# Altium schematic sheet Sheet_MCU_IO.SchDoc
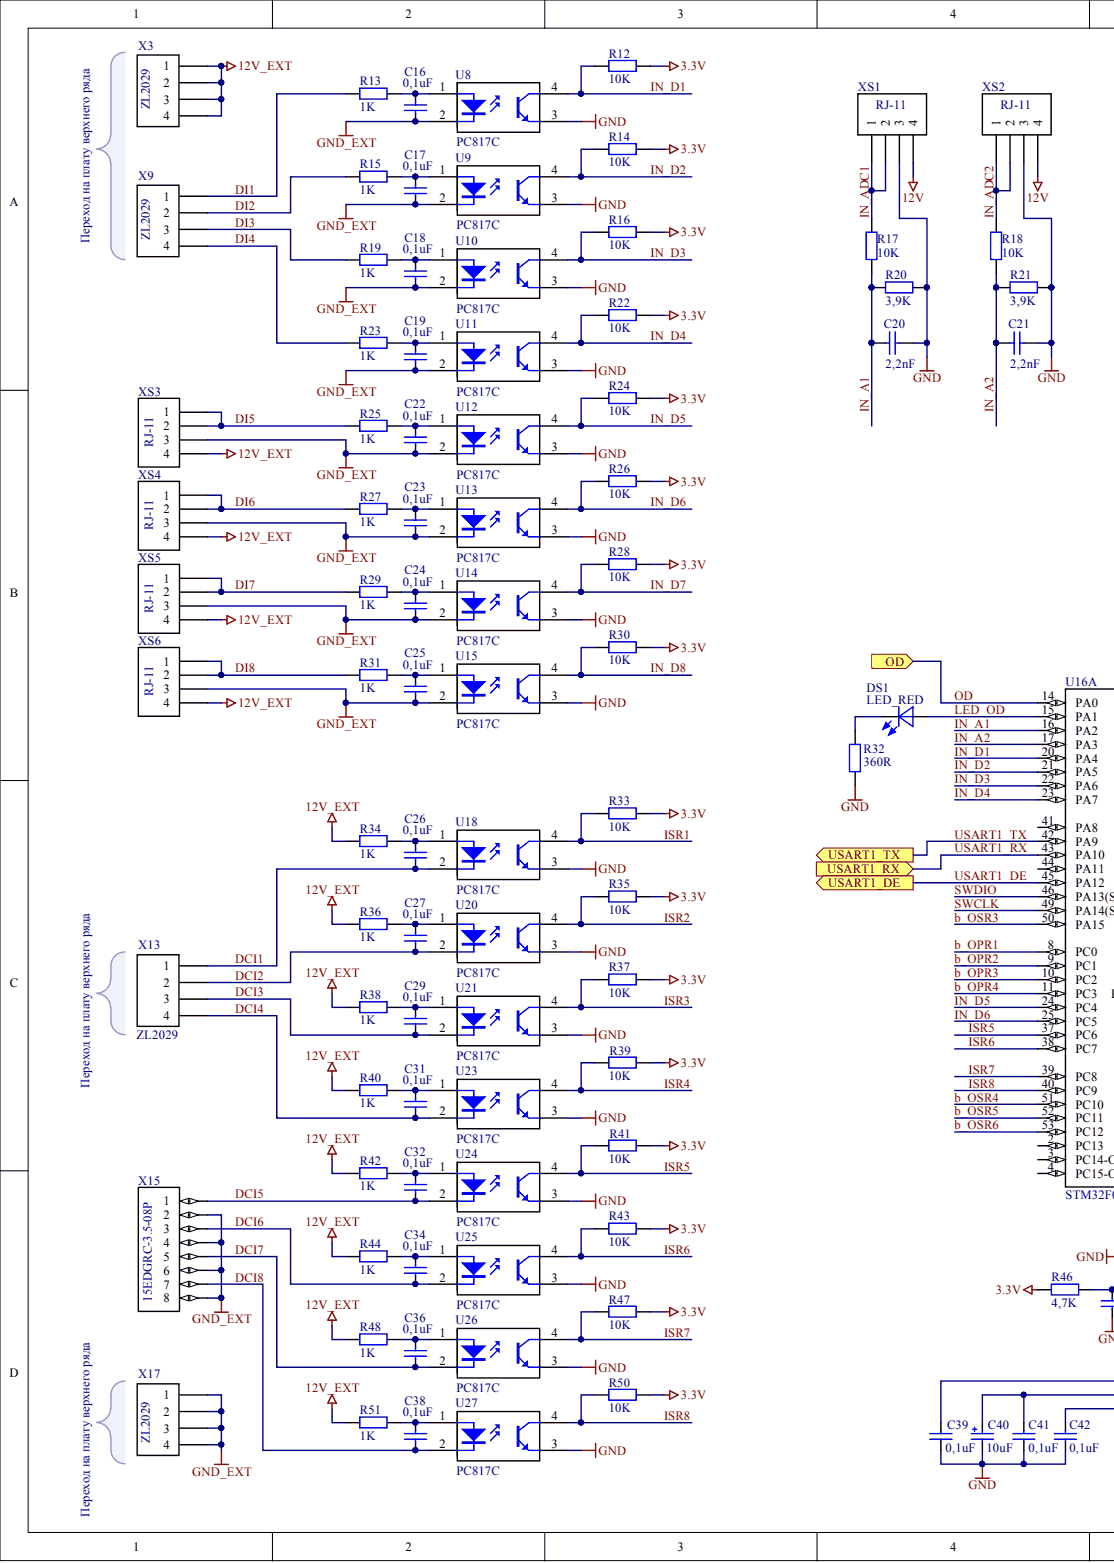
[diagram p0: Sheet_MCU_IO.SchDoc, left]
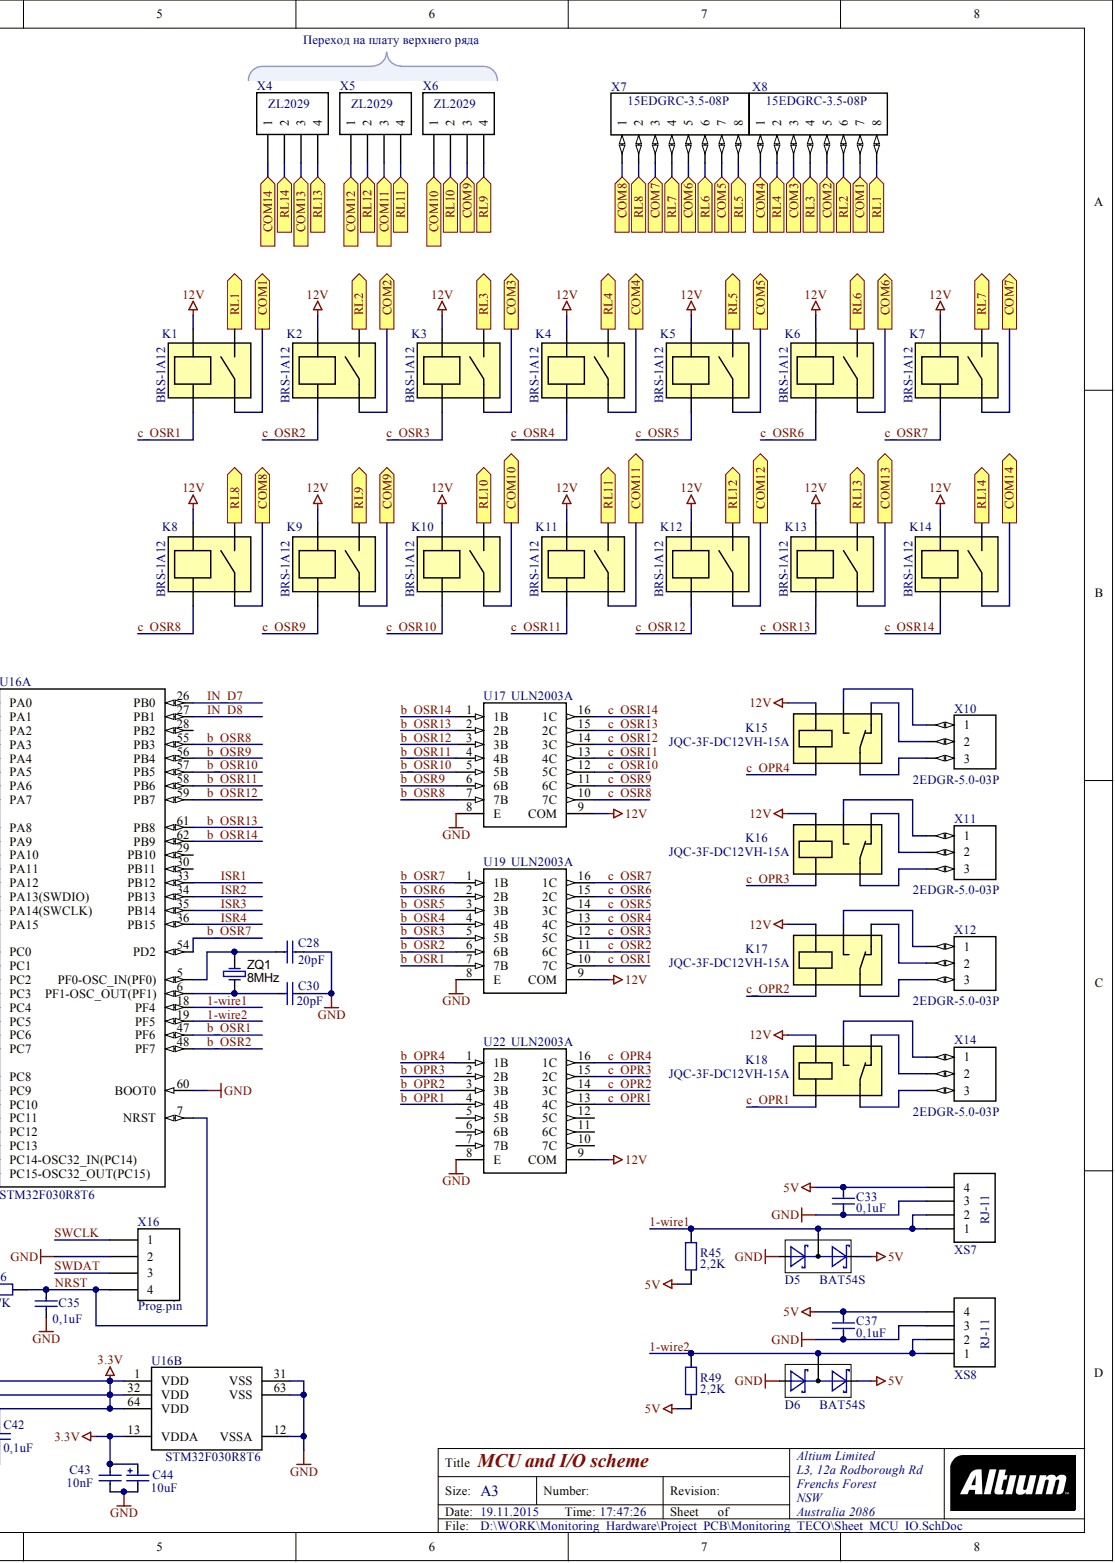
[diagram p1: Sheet_MCU_IO.SchDoc, right]

## Parts
C16  0,1uF  [C_0805]
C17  0,1uF  [C_0805]
C18  0,1uF  [C_0805]
C19  0,1uF  [C_0805]
C20  value 2,2nF  [C_0805]  (hidden comment: Cap_Semi)
C21  value 2,2nF  [C_0805]  (hidden comment: Cap_Semi)
C22  0,1uF  [C_0805]
C23  0,1uF  [C_0805]
C24  0,1uF  [C_0805]
C25  0,1uF  [C_0805]
C26  0,1uF  [C_0805]
C27  0,1uF  [C_0805]
C28  20pF  [C_0805]
C29  0,1uF  [C_0805]
C30  20pF  [C_0805]
C31  0,1uF  [C_0805]
C32  0,1uF  [C_0805]
C33  0,1uF  [C_0805]
C34  0,1uF  [C_0805]
C35  0,1uF  [C_0805]
C36  0,1uF  [C_0805]
C37  0,1uF  [C_0805]
C38  0,1uF  [C_0805]
C39  0,1uF  [C_0805]
C40  10uF  [5x11]
C41  0,1uF  [C_0805]
C42  0,1uF  [C_0805]
C43  10nF  [C_0805]
C44  10uF  [5x11]
D5  BAT54S  [SOT-23_d]
D6  BAT54S  [SOT-23_d]
DS1  LED_RED  [LED_RED]
K1  BRS-1A12  [R_BRS]
K2  BRS-1A12  [R_BRS]
K3  BRS-1A12  [R_BRS]
K4  BRS-1A12  [R_BRS]
K5  BRS-1A12  [R_BRS]
K6  BRS-1A12  [R_BRS]
K7  BRS-1A12  [R_BRS]
K8  BRS-1A12  [R_BRS]
K9  BRS-1A12  [R_BRS]
K10  BRS-1A12  [R_BRS]
K11  BRS-1A12  [R_BRS]
K12  BRS-1A12  [R_BRS]
K13  BRS-1A12  [R_BRS]
K14  BRS-1A12  [R_BRS]
K15  JQC-3F-DC12VH-15A  [BS-115C-12A]
K16  JQC-3F-DC12VH-15A  [BS-115C-12A]
K17  JQC-3F-DC12VH-15A  [BS-115C-12A]
K18  JQC-3F-DC12VH-15A  [BS-115C-12A]
R12  value 10K  [R_0805]  (hidden comment: Resistor)
R13  value 1K  [R_0805]  (hidden comment: Resistor)
R14  value 10K  [R_0805]  (hidden comment: Resistor)
R15  value 1K  [R_0805]  (hidden comment: Resistor)
R16  value 10K  [R_0805]  (hidden comment: Resistor)
R17  value 10K  [R_0805]  (hidden comment: Resistor)
R18  value 10K  [R_0805]  (hidden comment: Resistor)
R19  value 1K  [R_0805]  (hidden comment: Resistor)
R20  value 3,9K  [R_0805]  (hidden comment: Resistor)
R21  value 3,9K  [R_0805]  (hidden comment: Resistor)
R22  value 10K  [R_0805]  (hidden comment: Resistor)
R23  value 1K  [R_0805]  (hidden comment: Resistor)
R24  value 10K  [R_0805]  (hidden comment: Resistor)
R25  value 1K  [R_0805]  (hidden comment: Resistor)
R26  value 10K  [R_0805]  (hidden comment: Resistor)
R27  value 1K  [R_0805]  (hidden comment: Resistor)
R28  value 10K  [R_0805]  (hidden comment: Resistor)
R29  value 1K  [R_0805]  (hidden comment: Resistor)
R30  value 10K  [R_0805]  (hidden comment: Resistor)
R31  value 1K  [R_0805]  (hidden comment: Resistor)
R32  value 360R  [R_0805]  (hidden comment: Resistor)
R33  value 10K  [R_0805]  (hidden comment: Resistor)
R34  value 1K  [R_0805]  (hidden comment: Resistor)
R35  value 10K  [R_0805]  (hidden comment: Resistor)
R36  value 1K  [R_0805]  (hidden comment: Resistor)
R37  value 10K  [R_0805]  (hidden comment: Resistor)
R38  value 1K  [R_0805]  (hidden comment: Resistor)
R39  value 10K  [R_0805]  (hidden comment: Resistor)
R40  value 1K  [R_0805]  (hidden comment: Resistor)
R41  value 10K  [R_0805]  (hidden comment: Resistor)
R42  value 1K  [R_0805]  (hidden comment: Resistor)
R43  value 10K  [R_0805]  (hidden comment: Resistor)
R44  value 1K  [R_0805]  (hidden comment: Resistor)
R45  value 2,2K  [R_0805]  (hidden comment: Resistor)
R46  value 4,7K  [R_0805]  (hidden comment: Resistor)
R47  value 10K  [R_0805]  (hidden comment: Resistor)
R48  value 1K  [R_0805]  (hidden comment: Resistor)
R49  value 2,2K  [R_0805]  (hidden comment: Resistor)
R50  value 10K  [R_0805]  (hidden comment: Resistor)
R51  value 1K  [R_0805]  (hidden comment: Resistor)
U8  PC817C  [DIP-4]
U9  PC817C  [DIP-4]
U10  PC817C  [DIP-4]
U11  PC817C  [DIP-4]
U12  PC817C  [DIP-4]
U13  PC817C  [DIP-4]
U14  PC817C  [DIP-4]
U15  PC817C  [DIP-4]
U16  STM32F030R8T6  [LQFP64_L]  (parts A,B)
U17  ULN2003A  [SO-16_N]
U18  PC817C  [DIP-4]
U19  ULN2003A  [SO-16_N]
U20  PC817C  [DIP-4]
U21  PC817C  [DIP-4]
U22  ULN2003A  [SO-16_N]
U23  PC817C  [DIP-4]
U24  PC817C  [DIP-4]
U25  PC817C  [DIP-4]
U26  PC817C  [DIP-4]
U27  PC817C  [DIP-4]
X3  PLS-4  [PLS-4-3D]  (value not drawn)
X4  PLS-4  [PLS-4-3D]  (value not drawn)
X5  PLS-4  [PLS-4-3D]  (value not drawn)
X6  PLS-4  [PLS-4-3D]  (value not drawn)
X7  15EDGRC-3.5-08P  [MC_3.5_8]
X8  15EDGRC-3.5-08P  [MC_3.5_8]
X9  PLS-4  [PLS-4-3D]  (value not drawn)
X10  2EDGR-5.0-03P  [2EDGR-5.0-03P]
X11  2EDGR-5.0-03P  [2EDGR-5.0-03P]
X12  2EDGR-5.0-03P  [2EDGR-5.0-03P]
X13  PLS-4  [PLS-4-3D]  (value not drawn)
X14  2EDGR-5.0-03P  [2EDGR-5.0-03P]
X15  15EDGRC-3.5-08P  [MC_3.5_8]
X16  PLS-4  [PLS-4-3D]  (value not drawn)
X17  PLS-4  [PLS-4-3D]  (value not drawn)
XS1  RJ-11  [RJ-11 - TJ4-4P4C]
XS2  RJ-11  [RJ-11 - TJ4-4P4C]
XS3  RJ-11  [RJ-11 - TJ4-4P4C]
XS4  RJ-11  [RJ-11 - TJ4-4P4C]
XS5  RJ-11  [RJ-11 - TJ4-4P4C]
XS6  RJ-11  [RJ-11 - TJ4-4P4C]
XS7  RJ-11  [RJ-11 - TJ4-4P4C]
XS8  RJ-11  [RJ-11 - TJ4-4P4C]
ZQ1  8MHz  [HC49S_3D]

## Ports
COM1, COM2, COM3, COM4, COM5, COM6, COM7, COM8, COM9, COM10, COM11, COM12, COM13, COM14, OD, RL1, RL2, RL3, RL4, RL5, RL6, RL7, RL8, RL9, RL10, RL11, RL12, RL13, RL14, USART1_DE, USART1_RX, USART1_TX

## Nets
3.3V: C39.1 C40.1 C41.1 C42.1 C43.1 C44.1 R12.1 R14.1 R16.1 R22.1 R24.1 R26.1 R28.1 R30.1 R33.1 R35.1 R37.1 R39.1 R41.1 R43.1 R46.1 R47.1 R50.1 U16.1(VDD) U16.13(VDDA) U16.32(VDD) U16.64(VDD)
5V: C33.1 C37.1 D5.2 D6.2 R45.2 R49.2 XS7.4 XS8.4
12V: K1.3 K2.3 K3.3 K4.3 K5.3 K6.3 K7.3 K8.3 K9.3 K10.3 K11.3 K12.3 K13.3 K14.3 K15.1 K16.1 K17.1 K18.1 U17.9(COM) U19.9(COM) U22.9(COM) XS1.4 XS2.4
12V_EXT: R34.2 R36.2 R38.2 R40.2 R42.2 R44.2 R48.2 R51.2 X3.1 X3.2 X3.3 X3.4 XS3.4 XS4.4 XS5.4 XS6.4
GND: C20.2 C21.2 C28.1 C30.1 C33.2 C35.2 C37.2 C39.2 C40.2 C41.2 C42.2 C43.2 C44.2 D5.1 D6.1 R20.2 R21.2 R32.2 U8.3 U9.3 U10.3 U11.3 U12.3 U13.3 U14.3 U15.3 U16.12(VSSA) U16.31(VSS) U16.60(BOOT0) U16.63(VSS) U17.8(E) U18.3 U19.8(E) U20.3 U21.3 U22.8(E) U23.3 U24.3 U25.3 U26.3 U27.3 X16.2 XS1.3 XS2.3 XS7.3 XS8.3
GND_EXT: C16.2 C17.2 C18.2 C19.2 C22.2 C23.2 C24.2 C25.2 U8.2 U9.2 U10.2 U11.2 U12.2 U13.2 U14.2 U15.2 X15.2 X15.4 X15.6 X15.8 X17.1 X17.2 X17.3 X17.4 XS3.3 XS4.3 XS5.3 XS6.3
1-WIRE1: D5.3 R45.1 U16.18(PF4) XS7.1 XS7.2
1-WIRE2: D6.3 R49.1 U16.19(PF5) XS8.1 XS8.2
B_OPR1: U16.8(PC0) U22.4(4B)
B_OPR2: U16.9(PC1) U22.3(3B)
B_OPR3: U16.10(PC2) U22.2(2B)
B_OPR4: U16.11(PC3) U22.1(1B)
B_OSR1: U16.47(PF6) U19.7(7B)
B_OSR2: U16.48(PF7) U19.6(6B)
B_OSR3: U16.50(PA15) U19.5(5B)
B_OSR4: U16.51(PC10) U19.4(4B)
B_OSR5: U16.52(PC11) U19.3(3B)
B_OSR6: U16.53(PC12) U19.2(2B)
B_OSR7: U16.54(PD2) U19.1(1B)
B_OSR8: U16.55(PB3) U17.7(7B)
B_OSR9: U16.56(PB4) U17.6(6B)
B_OSR10: U16.57(PB5) U17.5(5B)
B_OSR11: U16.58(PB6) U17.4(4B)
B_OSR12: U16.59(PB7) U17.3(3B)
B_OSR13: U16.61(PB8) U17.2(2B)
B_OSR14: U16.62(PB9) U17.1(1B)
C_OPR1: K18.2 U22.13(4C)
C_OPR2: K17.2 U22.14(3C)
C_OPR3: K16.2 U22.15(2C)
C_OPR4: K15.2 U22.16(1C)
C_OSR1: K1.5 U19.10(7C)
C_OSR2: K2.5 U19.11(6C)
C_OSR3: K3.5 U19.12(5C)
C_OSR4: K4.5 U19.13(4C)
C_OSR5: K5.5 U19.14(3C)
C_OSR6: K6.5 U19.15(2C)
C_OSR7: K7.5 U19.16(1C)
C_OSR8: K8.5 U17.10(7C)
C_OSR9: K9.5 U17.11(6C)
C_OSR10: K10.5 U17.12(5C)
C_OSR11: K11.5 U17.13(4C)
C_OSR12: K12.5 U17.14(3C)
C_OSR13: K13.5 U17.15(2C)
C_OSR14: K14.5 U17.16(1C)
COM1: K1.7 X8.7 ; port COM1
COM2: K2.7 X8.5 ; port COM2
COM3: K3.7 X8.3 ; port COM3
COM4: K4.7 X8.1 ; port COM4
COM5: K5.7 X7.7 ; port COM5
COM6: K6.7 X7.5 ; port COM6
COM7: K7.7 X7.3 ; port COM7
COM8: K8.7 X7.1 ; port COM8
COM9: K9.7 X6.3 ; port COM9
COM10: K10.7 X6.1 ; port COM10
COM11: K11.7 X5.3 ; port COM11
COM12: K12.7 X5.1 ; port COM12
COM13: K13.7 X4.3 ; port COM13
COM14: K14.7 X4.1 ; port COM14
DCI1: C26.2 U18.2 X13.1
DCI2: C27.2 U20.2 X13.2
DCI3: C29.2 U21.2 X13.3
DCI4: C31.2 U23.2 X13.4
DCI5: C32.2 U24.2 X15.1
DCI6: C34.2 U25.2 X15.3
DCI7: C36.2 U26.2 X15.5
DCI8: C38.2 U27.2 X15.7
DI1: R13.2 X9.1
DI2: R15.2 X9.2
DI3: R19.2 X9.3
DI4: R23.2 X9.4
DI5: R25.2 XS3.1 XS3.2
DI6: R27.2 XS4.1 XS4.2
DI7: R29.2 XS5.1 XS5.2
DI8: R31.2 XS6.1 XS6.2
IN_A1: C20.1 R17.1 R20.1 U16.16(PA2)
IN_A2: C21.1 R18.1 R21.1 U16.17(PA3)
IN_ADC1: R17.2 XS1.1 XS1.2
IN_ADC2: R18.2 XS2.1 XS2.2
IN_D1: R12.2 U8.4 U16.20(PA4)
IN_D2: R14.2 U9.4 U16.21(PA5)
IN_D3: R16.2 U10.4 U16.22(PA6)
IN_D4: R22.2 U11.4 U16.23(PA7)
IN_D5: R24.2 U12.4 U16.24(PC4)
IN_D6: R26.2 U13.4 U16.25(PC5)
IN_D7: R28.2 U14.4 U16.26(PB0)
IN_D8: R30.2 U15.4 U16.27(PB1)
ISR1: R33.2 U16.33(PB12) U18.4
ISR2: R35.2 U16.34(PB13) U20.4
ISR3: R37.2 U16.35(PB14) U21.4
ISR4: R39.2 U16.36(PB15) U23.4
ISR5: R41.2 U16.37(PC6) U24.4
ISR6: R43.2 U16.38(PC7) U25.4
ISR7: R47.2 U16.39(PC8) U26.4
ISR8: R50.2 U16.40(PC9) U27.4
LED_OD: DS1.1 U16.15(PA1)
NRST: C35.1 R46.2 U16.7(NRST) X16.4
OD: U16.14(PA0) ; port OD
RL1: K1.1 X8.8 ; port RL1
RL2: K2.1 X8.6 ; port RL2
RL3: K3.1 X8.4 ; port RL3
RL4: K4.1 X8.2 ; port RL4
RL5: K5.1 X7.8 ; port RL5
RL6: K6.1 X7.6 ; port RL6
RL7: K7.1 X7.4 ; port RL7
RL8: K8.1 X7.2 ; port RL8
RL9: K9.1 X6.4 ; port RL9
RL10: K10.1 X6.2 ; port RL10
RL11: K11.1 X5.4 ; port RL11
RL12: K12.1 X5.2 ; port RL12
RL13: K13.1 X4.4 ; port RL13
RL14: K14.1 X4.2 ; port RL14
SWCLK: U16.49(PA14(SWCLK)) X16.1
SWDAT: X16.3
SWDIO: U16.46(PA13(SWDIO))
USART1_DE: U16.45(PA12) ; port USART1_DE
USART1_RX: U16.43(PA10) ; port USART1_RX
USART1_TX: U16.42(PA9) ; port USART1_TX
NetC16_1: C16.1 R13.1 U8.1
NetC17_1: C17.1 R15.1 U9.1
NetC18_1: C18.1 R19.1 U10.1
NetC19_1: C19.1 R23.1 U11.1
NetC22_1: C22.1 R25.1 U12.1
NetC23_1: C23.1 R27.1 U13.1
NetC24_1: C24.1 R29.1 U14.1
NetC25_1: C25.1 R31.1 U15.1
NetC26_1: C26.1 R34.1 U18.1
NetC27_1: C27.1 R36.1 U20.1
NetC28_2: C28.2 U16.5(PF0-OSC_IN(PF0)) ZQ1.2
NetC29_1: C29.1 R38.1 U21.1
NetC30_2: C30.2 U16.6(PF1-OSC_OUT(PF1)) ZQ1.1
NetC31_1: C31.1 R40.1 U23.1
NetC32_1: C32.1 R42.1 U24.1
NetC34_1: C34.1 R44.1 U25.1
NetC36_1: C36.1 R48.1 U26.1
NetC38_1: C38.1 R51.1 U27.1
NetDS1_2: DS1.2 R32.1
NetK15_3: K15.3 X10.3
NetK15_4: K15.4 X10.2
NetK15_5: K15.5 X10.1
NetK16_3: K16.3 X11.3
NetK16_4: K16.4 X11.2
NetK16_5: K16.5 X11.1
NetK17_3: K17.3 X12.3
NetK17_4: K17.4 X12.2
NetK17_5: K17.5 X12.1
NetK18_3: K18.3 X14.3
NetK18_4: K18.4 X14.2
NetK18_5: K18.5 X14.1

Not connected: U16 2(PC13) 3(PC14-OSC32_IN(PC14)) 4(PC15-OSC32_OUT(PC15)) 28(PB2) 29(PB10) 30(PB11) 41(PA8) 44(PA11); U22 5(5B) 6(6B) 7(7B) 10(7C) 11(6C) 12(5C)

## Library data of the parts
C16-C19 C22-C39 C41-C43 = Cap_Semi: Capacitor semi; Component Kind: Standard; Library Name: Capacitor.SchLib
C20 C21 = Cap_Semi: Capacitor Semi; Component Kind: Standard; Library Name: Capacitor.SchLib
C40 C44 = Capacitor Polar: Component Kind: Standard; Description: Capacitor polar; Library Name: Capacitor.SchLib
D5 D6 = BAT54S: Diode Schottky; Component Kind: Standard; Library Name: Diode.SchLib
DS1 = LED_RED: LED; Component Kind: Standard; Library Name: LEDs.SchLib
K1-K14 = BRS-1A12: 1A, 10Vdc, 1 pole, SIP terminal; Component Kind: Standard; Library Name: Relay.SchLib
K15-K18 = JQC-3F-DC12VH-7A: 7A 240Vac 1 pole Slim relay; Component Kind: Standard; Library Name: Relay.SchLib
U8-U15 U18 U20 U21 U23-U27 = PC817: Optocoupler; Component Kind: Standard; Library Name: Chip_Packaging.SchLib
U16 = STM32F030R8T6: ARM Cortex-M0 32-bit MCU, 64 KB Flash, 8 KB Internal RAM, 55 I/Os, 64-pin LQFP, -40 to 85 degC, Tray; Component Kind: Standard; Library Name: Chip_Packaging.SchLib
U17 U19 U22 = ULN2003A: Darlington Transistor Arrays; Component Kind: Standard; Library Name: Chip_Packaging.SchLib
X3-X6 X9 X13 X16 X17 = PLS-4: Header, 4-Pin; Component Kind: Standard; LatestRevisionDate: 17-Jul-2002; LatestRevisionNote: Re-released for DXP Platform.; Library Name: Connect.SchLib; Publisher: Altium Limited
  X3-X6 X9 X13 X17: : ZL2029
  X16: : Prog.pin
X7 X8 X15 = MC_3.5_8: Connector 3.5mm; Component Kind: Standard; Library Name: Connect.SchLib
X10-X12 X14 = 2EDGR-5.0-03P: Connector 5mm; Component Kind: Standard; Library Name: Connect.SchLib
XS1-XS8 = RJ-11: Connector RJ-11; Component Kind: Standard; Library Name: Connect.SchLib
ZQ1 = XTAL: Crystal Oscillator; Component Kind: Standard; LatestRevisionDate: 17-Jul-2002; LatestRevisionNote: Re-released for DXP Platform.; Library Name: Oscillator.SchLib; PackageReference: R38; Published: 8-Jun-2000; Publisher: Altium Limited
R12-R51 = Resistor: Component Kind: Standard; Description: Resistor; Library Name: Resistors.SchLib
